# Altium schematic sheet Linefollow.SchDoc
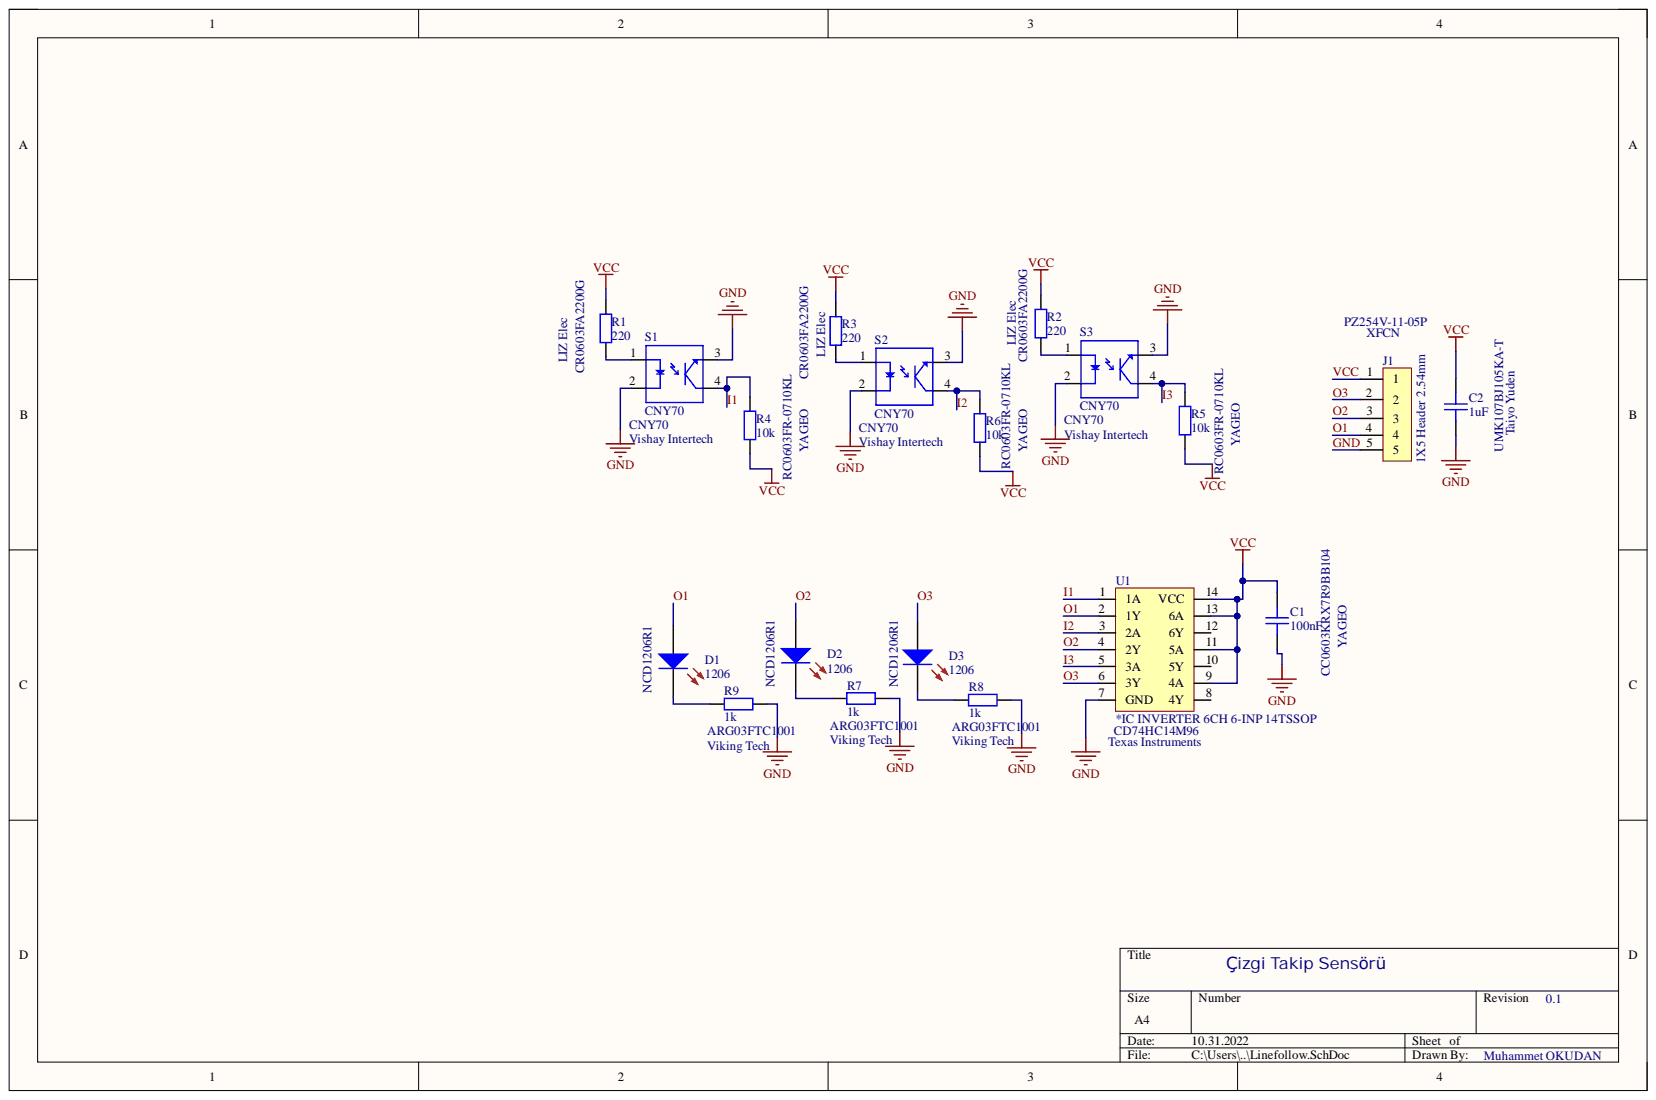
[diagram p0: Linefollow.SchDoc]

## Parts
C1  100nF  [0603 CAP]
C2  1uF  [0603 CAP]
D1  1206  *Mfg Part#: NCD1206R1  [LED KIRMIZI 1206]
D2  1206  *Mfg Part#: NCD1206R1  [LED KIRMIZI 1206]
D3  1206  *Mfg Part#: NCD1206R1  [LED KIRMIZI 1206]
J1  1X5 Header 2.54mm  [1x5header 2.54mm]
R1  220  *Mfg Part#: CR0603FA2200G  [0603 RES]
R2  220  *Mfg Part#: CR0603FA2200G  Manufacturer: LIZ Elec  [0603 RES]
R3  220  *Mfg Part#: CR0603FA2200G  Manufacturer: LIZ Elec  [0603 RES]
R4  10k  [0603 RES]
R5  10k  *Mfg Part#: RC0603FR-0710KL  [0603 RES]
R6  10k  *Mfg Part#: RC0603FR-0710KL  [0603 RES]
R7  1k  *Mfg Part#: ARG03FTC1001  [0603 RES]
R8  1k  *Mfg Part#: ARG03FTC1001  [0603 RES]
R9  1k  *Mfg Part#: ARG03FTC1001  [0603 RES]
S1  CNY70  [CNY70]
S2  CNY70  [CNY70]
S3  CNY70  [CNY70]
U1  *IC INVERTER 6CH 6-INP 14TSSOP  *Mfg Part#: CD74HC14M96  [74HC14]

## Nets
GND: C1.1 C2.1 J1.5 R7.1 R8.1 R9.1 S1.2 S1.3 S2.2 S2.3 S3.2 S3.3 U1.7(GND)
I1: R4.2 S1.4 U1.1(1A)
I2: R6.2 S2.4 U1.3(2A)
I3: R5.2 S3.4 U1.5(3A)
O1: D1.1 J1.4 U1.2(1Y)
O2: D2.1 J1.3 U1.4(2Y)
O3: D3.1 J1.2 U1.6(3Y)
VCC: C1.2 C2.2 J1.1 R1.2 R2.2 R3.2 R4.1 R5.1 R6.1 U1.9(4A) U1.11(5A) U1.13(6A) U1.14(VCC)
NetD1_2: D1.2 R9.2
NetD2_2: D2.2 R7.2
NetD3_2: D3.2 R8.2
NetR1_1: R1.1 S1.1
NetR2_1: R2.1 S3.1
NetR3_1: R3.1 S2.1

Not connected: U1 8(4Y) 10(5Y) 12(6Y)

## Library data of the parts
C1 = CAP: 0603 CAP SMD; *Mfg Part#: CC0603KRX7R9BB104; Manufacturer: YAGEO; Component Kind: Standard; Library Name: Linefollow.SchLib
C2 = CAP: 0603 CAP SMD; *Mfg Part#: UMK107BJ105KA-T; Manufacturer: Taiyo Yuden; Component Kind: Standard; Library Name: Linefollow.SchLib
D1-D3 = LED KIRMIZI: 1206 LED SMD; Manufacturer: Foshan NationStar Optoelectronics; Component Kind: Standard; Library Name: Linefollow.SchLib
J1 = 1X5 Header 2.54mm: *Mfg Part#: PZ254V-11-05P; Manufacturer: XFCN; Component Kind: Standard; Library Name: Linefollow.SchLib
R1 = RES: 0603 RES SMD; Manufacturer: LIZ Elec; Component Kind: Standard; Library Name: Linefollow.SchLib
R2 R3 = RES: 0603 RES SMD; Component Kind: Standard; Library Name: Linefollow.SchLib
R4 = RES: 0603 RES SMD; *Mfg Part#: RC0603FR-0710KL; Manufacturer: YAGEO; Component Kind: Standard; Library Name: Linefollow.SchLib
R5 R6 = RES: 0603 RES SMD; Manufacturer: YAGEO; Component Kind: Standard; Library Name: Linefollow.SchLib
R7-R9 = RES: 0603 RES SMD; Manufacturer: Viking Tech; Component Kind: Standard; Library Name: Linefollow.SchLib
S1-S3 = CNY70: CNY70 Kızılötesi Sensör; Manufacturer: Vishay Intertech; *Mfg Part#: CNY70; Component Kind: Standard; Library Name: Linefollow.SchLib
U1 = 74HC14: Inverter IC 6 Channel Schmitt Trigger 14-TSSOP; Manufacturer: Texas Instruments; Component Kind: Standard; Library Name: Linefollow.SchLib
